# Altium schematic sheet NEH7100.SchDoc
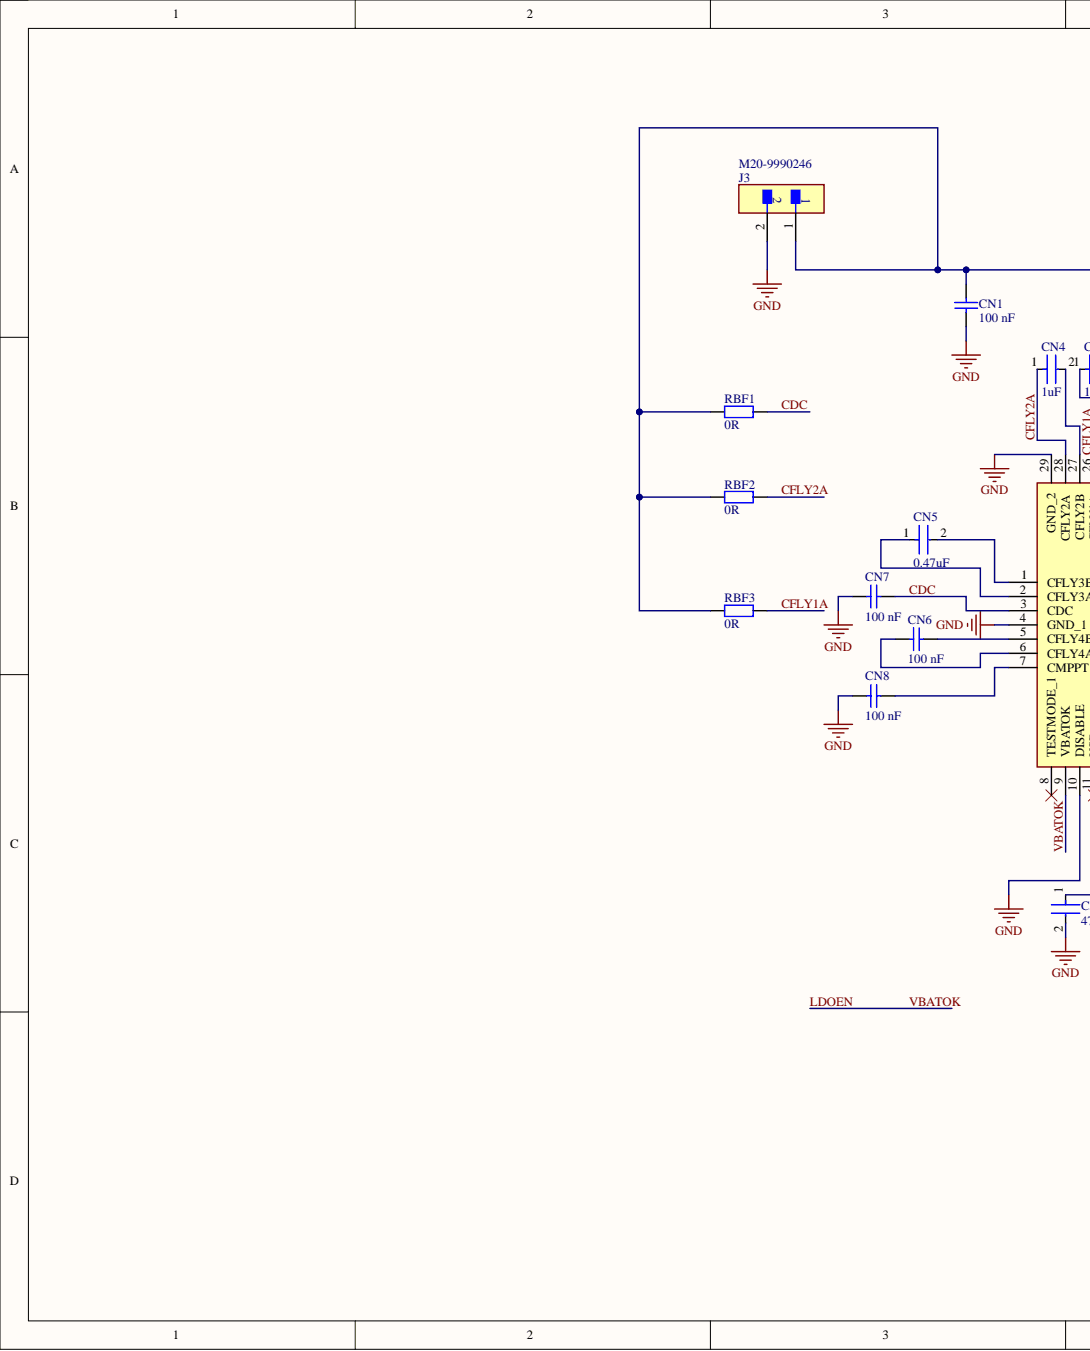
[diagram p0: NEH7100.SchDoc, left]
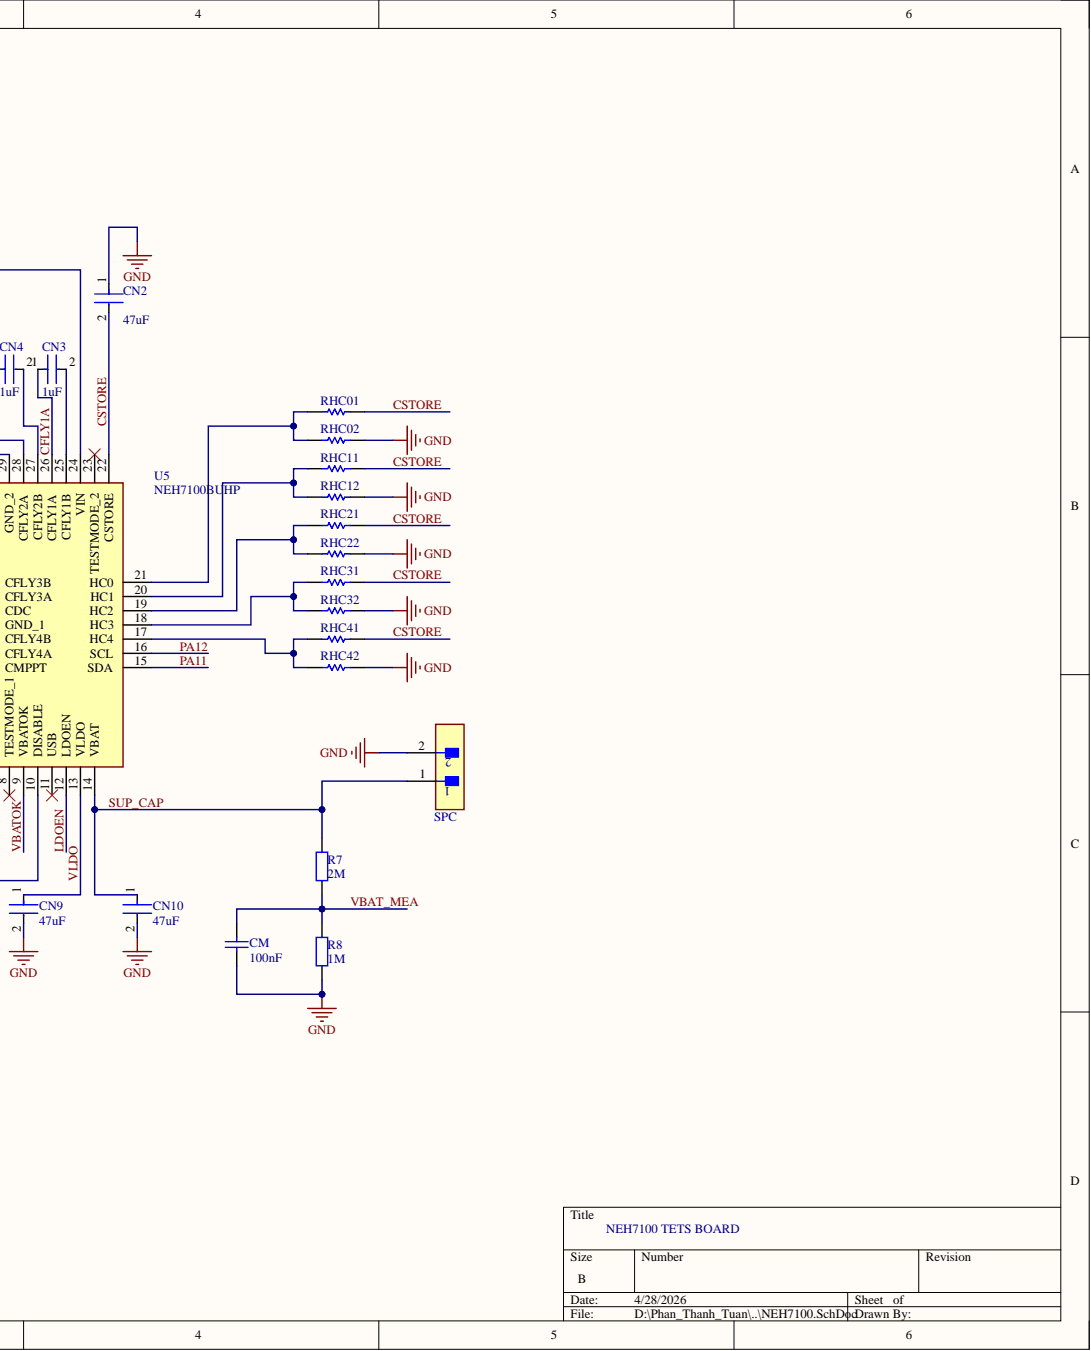
[diagram p1: NEH7100.SchDoc, right]

## Parts
CM  value 100nF  [rlc_402_smd]  (hidden comment: Cap)
CN1  Capacitance: 100 nF  [CAPC1005X55X25ML5T10]  (hidden comment: GRM155R61A104KA01D)
CN2  Capacitance: 47uF  [FP-GRM188-0_2-IPC_B]  (hidden comment: GRM188R60J476ME15D)
CN3  Capacitance: 1uF  [FP-GRM153-0_05-e0_1_0_35-IPC_B]  (hidden comment: GRM153R61A105ME95D)
CN4  Capacitance: 1uF  [FP-GRM153-0_05-e0_1_0_35-IPC_B]  (hidden comment: GRM153R61A105ME95D)
CN5  value 0.47uF  Capacitance: 0.47uF  [FP-GRM15-0_1-MFG]  (hidden comment: GRM155R61A474KE15D)
CN6  Capacitance: 100 nF  [CAPC1005X55X25ML5T10]  (hidden comment: GRM155R61A104KA01D)
CN7  Capacitance: 100 nF  [CAPC1005X55X25ML5T10]  (hidden comment: GRM155R61A104KA01D)
CN8  Capacitance: 100 nF  [CAPC1005X55X25ML5T10]  (hidden comment: GRM155R61A104KA01D)
CN9  Capacitance: 47uF  [FP-GRM188-0_2-IPC_B]  (hidden comment: GRM188R60J476ME15D)
CN10  Capacitance: 47uF  [FP-GRM188-0_2-IPC_B]  (hidden comment: GRM188R60J476ME15D)
J3  M20-9990246  [FP-M20-9990246-MFG]
R7  value 2M  [rlc_402_smd]  (hidden comment: Resistor)
R8  value 1M  [rlc_402_smd]  (hidden comment: Resistor)
RBF1  value 0R  [RES-SMD-0201]  (hidden comment: Resistor)
RBF2  value 0R  [RES-SMD-0201]  (hidden comment: Resistor)
RBF3  value 0R  [RES-SMD-0201]  (hidden comment: Resistor)
RHC01  RC1005J000CS  [RESC1005X40X25LL05T10]  (value not drawn)
RHC02  RC1005J000CS  [RESC1005X40X25LL05T10]  (value not drawn)
RHC11  RC1005J000CS  [RESC1005X40X25LL05T10]  (value not drawn)
RHC12  RC1005J000CS  [RESC1005X40X25LL05T10]  (value not drawn)
RHC21  RC1005J000CS  [RESC1005X40X25LL05T10]  (value not drawn)
RHC22  RC1005J000CS  [RESC1005X40X25LL05T10]  (value not drawn)
RHC31  RC1005J000CS  [RESC1005X40X25LL05T10]  (value not drawn)
RHC32  RC1005J000CS  [RESC1005X40X25LL05T10]  (value not drawn)
RHC41  RC1005J000CS  [RESC1005X40X25LL05T10]  (value not drawn)
RHC42  RC1005J000CS  [RESC1005X40X25LL05T10]  (value not drawn)
SPC  M20-9990246  [FP-M20-9990246-MFG]
U5  NEH7100BUHP  [QFN40P400X400X90-29N-D]

## Nets
GND: CM.1 CN1.2 CN2.1 CN7.2 CN8.2 CN9.2 CN10.2 J3.2 R8.1 RHC02.2 RHC12.2 RHC22.2 RHC32.2 RHC42.2 SPC.2 U5.4(GND_1) U5.10(DISABLE) U5.29(GND_2)
CDC: CN7.1 RBF1.2 U5.3(CDC)
CFLY1A: CN3.1 RBF3.2 U5.26(CFLY1A)
CFLY2A: CN4.1 RBF2.2 U5.28(CFLY2A)
CSTORE: CN2.2 RHC01.2 RHC11.2 RHC21.2 RHC31.2 RHC41.2 U5.22(CSTORE)
LDOEN: U5.9(VBATOK) U5.12(LDOEN)
PA11: U5.15(SDA)
PA12: U5.16(SCL)
SUP_CAP: CN10.1 R7.1 SPC.1 U5.14(VBAT)
VBAT_MEA: CM.2 R7.2 R8.2
VLDO: CN9.1 U5.13(VLDO)
NetCN1_1: CN1.1 J3.1 RBF1.1 RBF2.1 RBF3.1 U5.24(VIN)
NetCN3_2: CN3.2 U5.25(CFLY1B)
NetCN4_2: CN4.2 U5.27(CFLY2B)
NetCN5_1: CN5.1 U5.2(CFLY3A)
NetCN5_2: CN5.2 U5.1(CFLY3B)
NetCN6_1: CN6.1 U5.6(CFLY4A)
NetCN6_2: CN6.2 U5.5(CFLY4B)
NetCN8_1: CN8.1 U5.7(CMPPT)
NetRHC01_1: RHC01.1 RHC02.1 U5.21(HC0)
NetRHC11_1: RHC11.1 RHC12.1 U5.20(HC1)
NetRHC21_1: RHC21.1 RHC22.1 U5.19(HC2)
NetRHC31_1: RHC31.1 RHC32.1 U5.18(HC3)
NetRHC41_1: RHC41.1 RHC42.1 U5.17(HC4)

Not connected: U5 8(TESTMODE_1) 11(USB) 23(TESTMODE_2)

## Library data of the parts
CM = Cap: Capacitor SMD; Component Kind: Standard; LatestRevisionDate: 05-oct-2007  -  Semtech; LatestRevisionNote: Re-released for DXP Platform.; Library Name: LR1110_e516v02b.SchLib; Published: 8-Jun-2000; Signal Integrity: Cap Semi; Simulation: CAP; Publisher: Altium Limited
CN1 CN6-CN8 = CMP-1662-00019-1: Chip Capacitor, 100 nF, +/- 10%, 10 V, -55 to 85 degC, 0402 (1005 Metric), RoHS, Tape and Reel; Type (Capacitors): X5R; Voltage Rating: 10 V; Case Code (Imperial): 0402; Case Code (Metric): 1005; Case/Package: 0402; Component Kind: Standard; ComponentLink1Description: Manufacturer URL; ComponentLink1URL: http://www.murata.com/; ComponentLink2Description: Datasheet; ComponentLink2URL: https://datasheet.ciiva.com/18102/getdatasheetpartid-74533-18102605.pdf; DatasheetVersion: 05/2014; Height: 0.5 mm; Length: 1 mm; Library Name: Altium Content Vault; Max Operating Temperature: 85 degC; Min Operating Temperature: -55 degC; Mount: Surface Mount; Mounting Technology: Surface Mount; Package Quantity: 1; PackageDescription: 2-Pin Surface Mount Device, Body 1 x 0.5 mm; Packaging: Cut Tape; PartNumber: GRM155R61A104KA01D; Pins: 2; Radiation Hardening: No; REACH SVHC: Unknown; RoHSCompliant: true; Tolerance: 10%; Voltage Rating (DC): 10 V; Width: 0.5 mm
CN2 CN9 CN10 = CMP-2000-05444-2: Chip Multilayer Ceramic Capacitors for General Purpose, 0603, 47uF, X5R, 15%, 20%, 6.3V; Case Code (Imperial): 0603; Case Code (Metric): 1608; Case/Package: 0603; Component Kind: Standard; Dielectric: X5R; Length: 0.063inch; Library Name: Altium Content Vault; Max Operating Temperature: 85°C; Min Operating Temperature: -55°C; Mount: Surface Mount; Packaging: Tape and Reel; Thickness: 0.039inch; Tolerance: 20%; Voltage Rating: 6.3V; Voltage Rating (DC): 6.3V; Width: 0.031inch
CN3 CN4 = CMP-2008-04028-2: Chip Multilayer Ceramic Capacitors for General Purpose, 0402, 1.0uF, X5R, 15%, 20%, 10V; Case Code (Imperial): 0402; Case Code (Metric): 1005; Case/Package: 0402; Component Kind: Standard; Dielectric: X5R; Height: 0.3mm; Length: 1mm; Library Name: Altium Content Vault; Material: Ceramic; Max Operating Temperature: 85°C; Min Operating Temperature: -55°C; Mount: Surface Mount; Package Quantity: 10000; Packaging: Tape and Reel; REACH SVHC: No SVHC; Resistor Type: Ceramic; Termination: SMD/SMT; Thickness: 0.013inch; Tolerance: 20%; Voltage Rating: 10V; Voltage Rating (DC): 10V; Weight: 0.000212oz; Width: 0.5mm
CN5 = CMP-2000-05009-2: CAP CER 0.47UF 10V X5R 0402; Manufacturer: Murata Electronics North America; Temperature Coefficient: 15%; Tolerance: 10%; Automotive: No; Category: Cap; Component Kind: Standard; ComponentLink2Description: Datasheet; ComponentLink2URL: https://search.murata.co.jp/Ceramy/image/img/A01X/G101/ENG/GRM155R61A474KE15-01A.pdf; Device Class L1: Passive Components; Device Class L2: Capacitors; Device Class L3: Ceramic Capacitors; Digikey Description: CAP CER 0.47UF 10V X5R 0402; Height: 0.55mm; Ipc Land Pattern Name: CAPC10050X50; Lead Free: Yes; Library Name: Altium Content Vault; Manufacturer Part Number: GRM155R61A474KE15D; Material: Ceramic; Mouser Description: Multilayer Ceramic Capacitors MLCC - SMD/SMT 0402 0.47uF 10volts X5R 10%; Octopart: https://octopart.com/grm155r61a474ke15d-murata-196883; Package: GRM15-0.1; RoHS: TRUE; Temperature: -55°C to +85°C; Temperature Characteristic: X5R; Temperature Range High: +85°C; Temperature Range Low: -55°C; Voltage Rating: 10V
J3 SPC = CMP-15831-000009-1: CONN HEADER VERT 2POS 2.54MM; Manufacturer: Harwin; Conn Gender: Male; Conn Orientation: Vertical; Current Rating: 3A; Number Of Contacts: 2; Automotive: No; Category: Conn; Component Kind: Standard; ComponentLink2Description: Datasheet; ComponentLink2URL: https://cdn.harwin.com/pdfs/M20-999.pdf; Contact Material: Tin,Nickel; Device Class L1: Connectors; Device Class L2: Headers and Wire Housings; Device Class L3: unset; Digikey Description: CONN HEADER VERT 2POS 2.54MM; Height: 8.99mm; Lead Free: Yes; Library Name: Altium Content Vault; Manufacturer Part Number: M20-9990246; Mouser Description: 2.54mm (0.1") Pitch SIL Vertical PC Tail Pin Header, 6.1mm mating pin height, tin, 2 contacts; Number Of Rows: 1; Octopart: https://octopart.com/m20-9990246-harwin-2590635; Package: M20-9990246; Pitch: 2.54mm; RoHS: TRUE; Temperature: -40°C to +105°C; Temperature Range High: +105°C; Temperature Range Low: -40°C
R7 R8 = Resistor: Resistor SMD - IEC symbol version; Component Kind: Standard; LatestRevisionDate: 27-Jul-20  -  Semtech; Library Name: LR1110_e516v02b.SchLib
RBF1-RBF3 = RES-SMD: Resistor SMD - IEC symbol version; Component Kind: Standard; LatestRevisionDate: 27-Jul-20  -  Semtech; Library Name: RFThings.SchLib
RHC01 RHC02 RHC11 RHC12 RHC21 RHC22 RHC31 RHC32 RHC41 RHC42 = CMP-2000-06964-1: Chip Resistor, 0 Ohm, +/- 5%, -55 to 125 degC, 0402 (1005 Metric), RoHS, Tape and Reel; Manufacturer: Samsung Electro-Mechanics; Rated Power: 0.063W; Resistance: 0 Ohm; Temp Coefficient: ±200ppm/°C; Component Kind: Standard; Datasheet URL: https://datasheet.ciiva.com/15196/getdatasheetpartid-499011-15196236.pdf; Datasheet Version: 11/2010; Library Name: Altium Content Vault; Manufacturer URL: http://www.samsungsem.com/global/index.jsp; Mounting Technology: SurfaceMount; Package Description: 2-Pin Surface Mount Device, Body 1 x 0.5 mm; Package Reference: 0402; Size Code: 0402; Tolerance (%): ±5%
U5 = NEH7100BUHP: Power Management Specialised - PMIC Inductorless energy harvesting PMIC with battery protection, LDO, I2C and USB charging, 140 mA, -40C to +85C; Manufacturer_Name: Nexperia; Geometry.Height: 0.9mm; Component Kind: Standard; Datasheet Link: https://assets.nexperia.com/documents/data-sheet/NEH7100.pdf; Library Name: NEH7100BUHP.IntLib; Manufacturer_Part_Number: NEH7100BUHP; Mouser Part Number: 771-NEH7100BUHP; Mouser Price/Stock: https://www.mouser.co.uk/ProductDetail/Nexperia/NEH7100BUHP?qs=%252BXxaIXUDbq0xGA7KvtEkLg%3D%3D
